# Altium schematic sheet Schematic 1.SchDoc
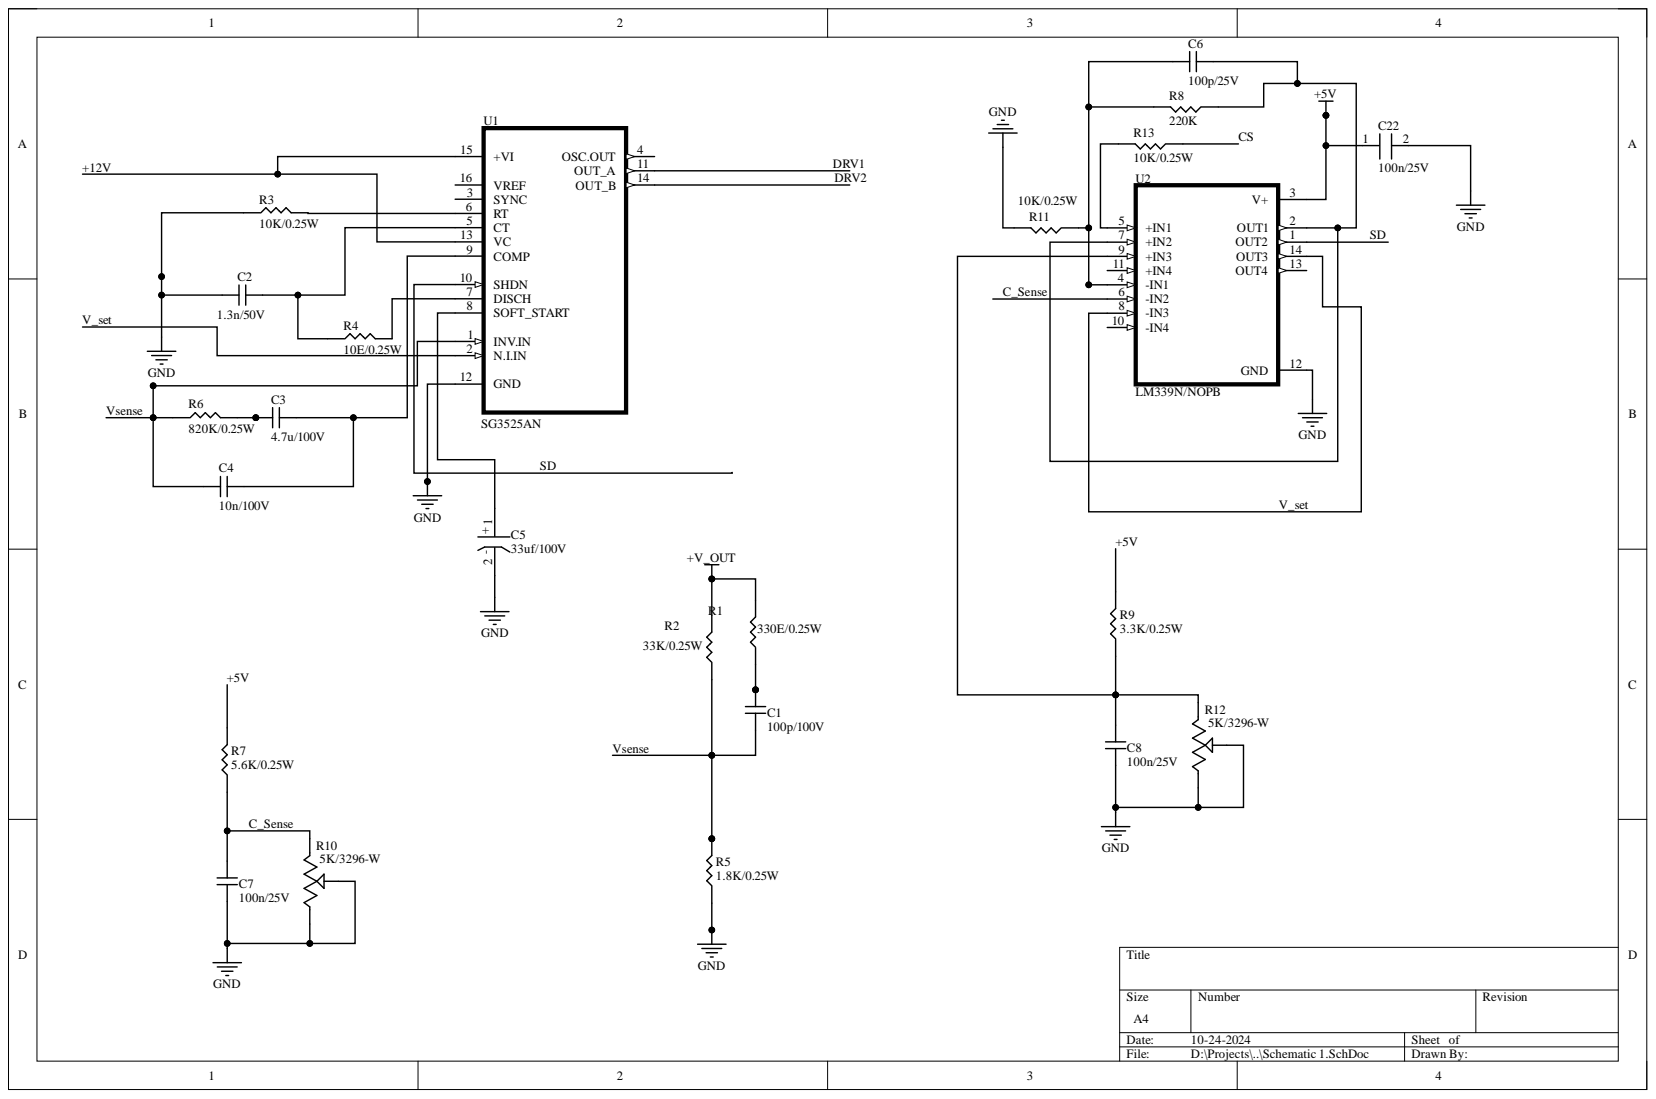
[diagram p0: Schematic 1.SchDoc]

## Parts
C1  100p/100V  [104-TH]
C2  1.3n/50V  [104-TH]
C3  4.7u/100V  [104-TH]
C4  10n/100V  [104-TH]
C5  33uf/100V  [8mm Dia Eletrolytic Cap]
C6  100p/25V  [104-TH]
C7  100n/25V  [104-TH]
C8  100n/25V  [104-TH]
C22  100n/25V  [Disc cap]
R1  330E/0.25W  [0.25W TH Resistor]
R2  33K/0.25W  [0.25W TH Resistor]
R3  10K/0.25W  [0.25W TH Resistor]
R4  10E/0.25W  [0.25W TH Resistor]
R5  1.8K/0.25W  [0.25W TH Resistor]
R6  820K/0.25W  [0.25W TH Resistor]
R7  5.6K/0.25W  [0.25W TH Resistor]
R8  220K  [0.25W TH Resistor]
R9  3.3K/0.25W  [0.25W TH Resistor]
R10  5K/3296-W  [TRIM_3296-W]
R11  10K/0.25W  [0.25W TH Resistor]
R12  5K/3296-W  [TRIM_3296-W]
R13  10K/0.25W  [0.25W TH Resistor]
U1  SG3525AN  [DIP254P762X510-16]
U2  LM339N/NOPB  [DIP794W45P254L1969H508Q14]

## Nets
+V_OUT: R1.2 R2.2
GND: C2.1 C5.2 C7.1 C8.1 C22.2 R3.1 R5.1 R10.1 R10.2 R11.2 R12.1 R12.2 U1.12(GND) U2.12(GND)
+5V: C22.1 R7.2 R9.2 U2.3(V+)
+12V: U1.13(VC) U1.15(+VI)
C_Sense: C7.2 R7.1 R10.3 U2.6(-IN2)
CS: R13.1
DRV1: U1.11(OUT_A)
DRV2: U1.14(OUT_B)
SD: U1.10(SHDN) U2.1(OUT2)
V_set: U1.2(N.I.IN) U2.8(-IN3) U2.14(OUT3)
Vsense: C1.2 C4.1 R2.1 R5.2 R6.1 U1.1(INV.IN)
NetC1_1: C1.1 R1.1
NetC2_2: C2.2 R4.1 U1.5(CT)
NetC3_1: C3.1 R6.2
NetC3_2: C3.2 C4.2 U1.9(COMP)
NetC5_1: C5.1 U1.8(SOFT_START)
NetC6_1: C6.1 R8.1 U2.2(OUT1) U2.7(+IN2)
NetC6_2: C6.2 R8.2 R11.1 U2.4(-IN1)
NetC8_2: C8.2 R9.1 R12.3 U2.9(+IN3)
NetR3_2: R3.2 U1.6(RT)
NetR4_2: R4.2 U1.7(DISCH)
NetR13_2: R13.2 U2.5(+IN1)

Not connected: U1 3(SYNC) 4(OSC.OUT) 16(VREF); U2 10(-IN4) 11(+IN4) 13(OUT4)

## Library data of the parts
C1-C4 C6-C8 = 104-TH: Component Kind: Standard; Library Name: Custom1.IntLib
C5 = 8 Dia Cap: Component Kind: Standard; Library Name: Custom1.IntLib
C22 = Disc cap: Component Kind: Standard; Library Name: Custom1.IntLib
R1-R9 R11 R13 = 0.25W TH resistor: Component Kind: Standard; Library Name: Custom1.IntLib
R10 R12 = 3296-W: 5 kOhms 0.5W, 1/2W PC Pins Through Hole Trimmer Potentiometer Cermet 25 Turn Top Adjustment; MF: Bourns; Availability: Unavailable; Component Kind: Standard; Library Name: 3296-W.IntLib; MP: 3296-W; Package: SOT-23-5 Bourns; Price: None; SnapEDA_Link: https://www.snapeda.com/parts/3296-W/Bourns/view-part/703041/?ref=snap
U1 = SG3525AN: PWM CONTROLLER, DUAL, V MODE, 500KHZ; Voltage, Input Max:35V; Max Output Current:0.5A; Voltage, Output Max:5.2V; No. of Outputs:2; Power Dissipation:1000mW; Frequency:400kHz; Voltage, Supply Min:4.5V; Voltage, Supply Max:35V; Case Style:PDIL; No. of Pins:16; Operating Temperature Range:0°C to +70°C; Temp, Op. Max:70°C; Temp, Op. Min:0°C; Base Number:3525; IC Generic Number:3525; Logic Function Number:3525; Power Supply IC Type:PWM controller; Temperature Range, IC:Commercial; Voltage, Output:5.1V; Voltage, Output Min:5.0V; MF: ON; Check_prices: https://www.snapeda.com/parts/SG3525AN/Onsemi/view-part/?ref=eda; Component Kind: Standard; Library Name: SG3525AN.IntLib; MP: SG3525AN; Package: PDIP-16 ON Semiconductor; SnapEDA_Link: https://www.snapeda.com/parts/SG3525AN/Onsemi/view-part/?ref=snap
U2 = LM339N/NOPB: Low Power Low Offset Voltage Quad Comparator; MF: Texas Instruments; Availability: In Stock; Check_prices: https://www.snapeda.com/parts/LM339N/NOPB/Texas+Instruments/view-part/?ref=eda; Component Kind: Standard; Library Name: LM339N_NOPB.IntLib; MP: LM339N/NOPB; Package: PDIP-14 Texas Instruments; Price: None; Purchase-URL: https://pricing.snapeda.com/search/part/LM339N/NOPB/?ref=eda; SnapEDA_Link: https://www.snapeda.com/parts/LM339N/NOPB/Texas+Instruments/view-part/?ref=snap
